# Altium schematic sheet UART_Bridge.SchDoc
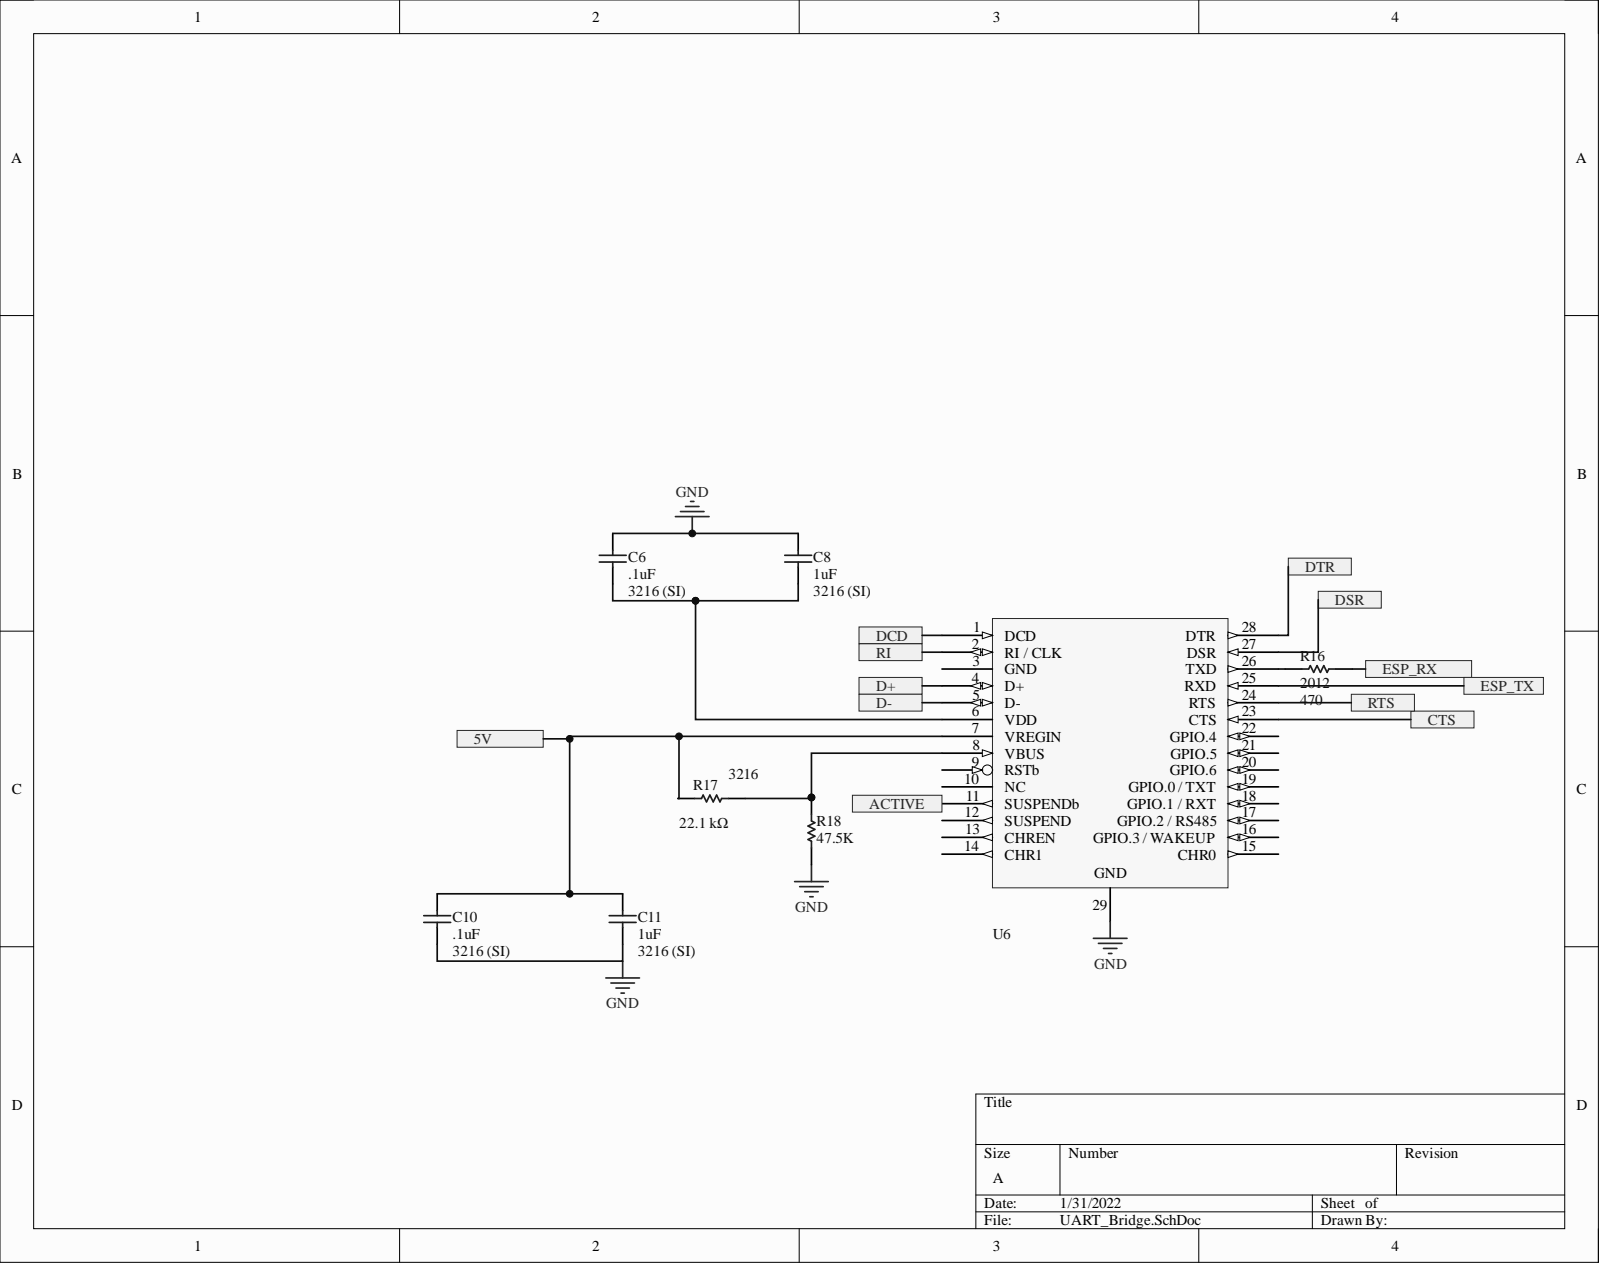
[diagram p0: UART_Bridge.SchDoc]

## Parts
C6  .1uF  [CSMD1206GRID25PADB]
C8  1uF  [CSMD1206GRID25PADB]
C10  .1uF  [CSMD1206GRID25PADB]
C11  1uF  [CSMD1206GRID25PADB]
R16  Case/Package (SI): 2012  [RESC2013X70X40ML20T20]  (hidden comment: Panasonic ERJ-6GEYJ822V)
R17  Case/Package (SI): 3216  Resistance: 22.1 kΩ  [RESC3216X60X45LL10T20]  (hidden comment: Vishay Dale CRCW120622K1FKEA)
R18  47.5K  [PCB-xjrijsl9d1yhuxpirg1j-1]
U6  CP2102N-A01-GQFN28  [CP2102N-A01-GQFN28]  (value not drawn)

## Ports
5V, ACTIVE, CTS, D+, D-, DCD, DSR, DTR, ESP_RX, ESP_TX, RI, RTS

## Nets
GND: C6.2 C8.2 C10.1 C11.1 R18.1 U6.29
5V: C10.2 C11.2 R17.1 U6.7(VREGIN) ; port 5V
ACTIVE: U6.11(SUSPENDb) ; port ACTIVE
CTS: U6.23(CTS) ; port CTS
D+: U6.4(D+) ; port D+
D-: U6.5(D-) ; port D-
DCD: U6.1(DCD) ; port DCD
DSR: U6.27(DSR) ; port DSR
DTR: U6.28(DTR) ; port DTR
ESP_RX: R16.1 ; port ESP_RX
ESP_TX: U6.25(RXD) ; port ESP_TX
RI: U6.2(RI_/_CLK) ; port RI
RTS: U6.24(RTS) ; port RTS
NetC6_1: C6.1 C8.1 U6.6(VDD)
NetR16_2: R16.2 U6.26(TXD)
NetR17_2: R17.2 R18.2 U6.8(VBUS)

Not connected: U6 3(GND) 9(RSTb) 10(NC) 12(SUSPEND) 13(CHREN) 14(CHR1) 15(CHR0) 16(GPIO.3_/_WAKEUP) 17(GPIO.2_/_RS485) 18(GPIO.1_/_RXT) 19(GPIO.0_/_TXT) 20(GPIO.6) 21(GPIO.5) 22(GPIO.4)

## Library data of the parts
C6 C10 = CMP-150561-3: Cap Ceramic 1uF 25V X7R 20% SMD 1206 125C Plastic T/R; Manufacturing Part Number: ‎C1206C104K5RAC7800‎; Lead-Free Status: R; Voltage Rating (DC): 25.0 V; Capacitance Tolerance: ±20%; Component Kind: Standard; Library Name: CommunityVault; Mounting Style: Surface Mount; Octopart Url: https://octopart.com/c1206c105m3ractu-kemet-8293447; OctopartID: f0ac39d8598d2f6b; Package/Case: 3216 (SI); Packaging: Cut Tape (CT); RoHS: Compliant
C8 C11 = CMP-150561-3: Cap Ceramic 1uF 25V X7R 20% SMD 1206 125C Plastic T/R; Lead-Free Status: R; Voltage Rating (DC): 25.0 V; Capacitance Tolerance: ±20%; Component Kind: Standard; Library Name: CommunityVault; Mounting Style: Surface Mount; Octopart Url: https://octopart.com/c1206c105m3ractu-kemet-8293447; OctopartID: f0ac39d8598d2f6b; Package/Case: 3216 (SI); Packaging: Cut Tape (CT); RoHS: Compliant
R16 = CMP-3687578-2: Thick Film Resistors - SMD 0805 8.2Kohms 5% Tol; Lead-Free Status: Lead Free; Power Rating: 0.125 W; Resistance: 470; Voltage Rating (DC): 150.0 V; Case/Package: 0805; Component Kind: Standard; Library Name: CommunityVault; Lifecycle Status: Not Listed by Manufacturer; Manufacturer Part Number: ERJ-6GEYJ822V; Mounting Style: Surface Mount; Octopart Url: https://octopart.com/erj-6geyj822v-panasonic-55423066; OctopartID: 0556cf11906ada91; Packaging: Cut Tape (CT); Resistance Tolerance: ±5%; RoHS: Compliant; Size-Height: 0.0006 m; Size-Length: 0.002 m; Size-Width: 0.00125 m
R17 = CMP-3944757-2: RES SMD 22.1K OHM 1% 1/4W 1206; Lead-Free Status: Lead Free; Power Rating: 0.25 W; Temperature Coefficient: 100.0 ppm/°C; Voltage Rating (DC): 200.0 V; Case/Package: 1206; Component Kind: Standard; Library Name: CommunityVault; Manufacturer Part Number: CRCW120622K1FKEA; Mounting Style: Surface Mount; Number of Pins: 2; Octopart Url: https://octopart.com/crcw120622k1fkea-vishay+dale-39794055; OctopartID: 48d1838458309716; REACH SVHC Compliance: No SVHC; Resistance Tolerance: ±1%; RoHS: Compliant; Size-Height: 0.00055 m; Size-Length: 0.0032 m; Size-Width: 0.0016 m
R18 = CMP-1046d86901e4cf81-1: Res Thick Film 1206 47.5K Ohm 1% 0.25W(1/4W) ±100ppm/C Pad SMD Automotive T/R; Manufacturer Part Number: RMCF1206FT47K5; Lead-Free Status: Lead Free; Case/Package: 1206; Component Kind: Standard; Library Name: CommunityVault; Mounting Style: Surface Mount; Octopart URL: https://octopart.com/rmcf1206ft47k5-stackpole+electronics-19215556; OctopartID: 1046d86901e4cf81; Packaging: Tape & Reel (TR); Resistance Tolerance: ±1%
U6 = CMP-0432c8ca4675dbf5-4: Full Speed USB to UART Bridge USB 2.0 3.3V T/R Automotive 28-Pin QFN EP; Component Kind: Standard; Library Name: CommunityVault; Octopart URL: https://octopart.com/cp2102n-a02-gqfn28r-silicon+labs-99772193
